# Altium schematic sheet Power.SchDoc(Power)
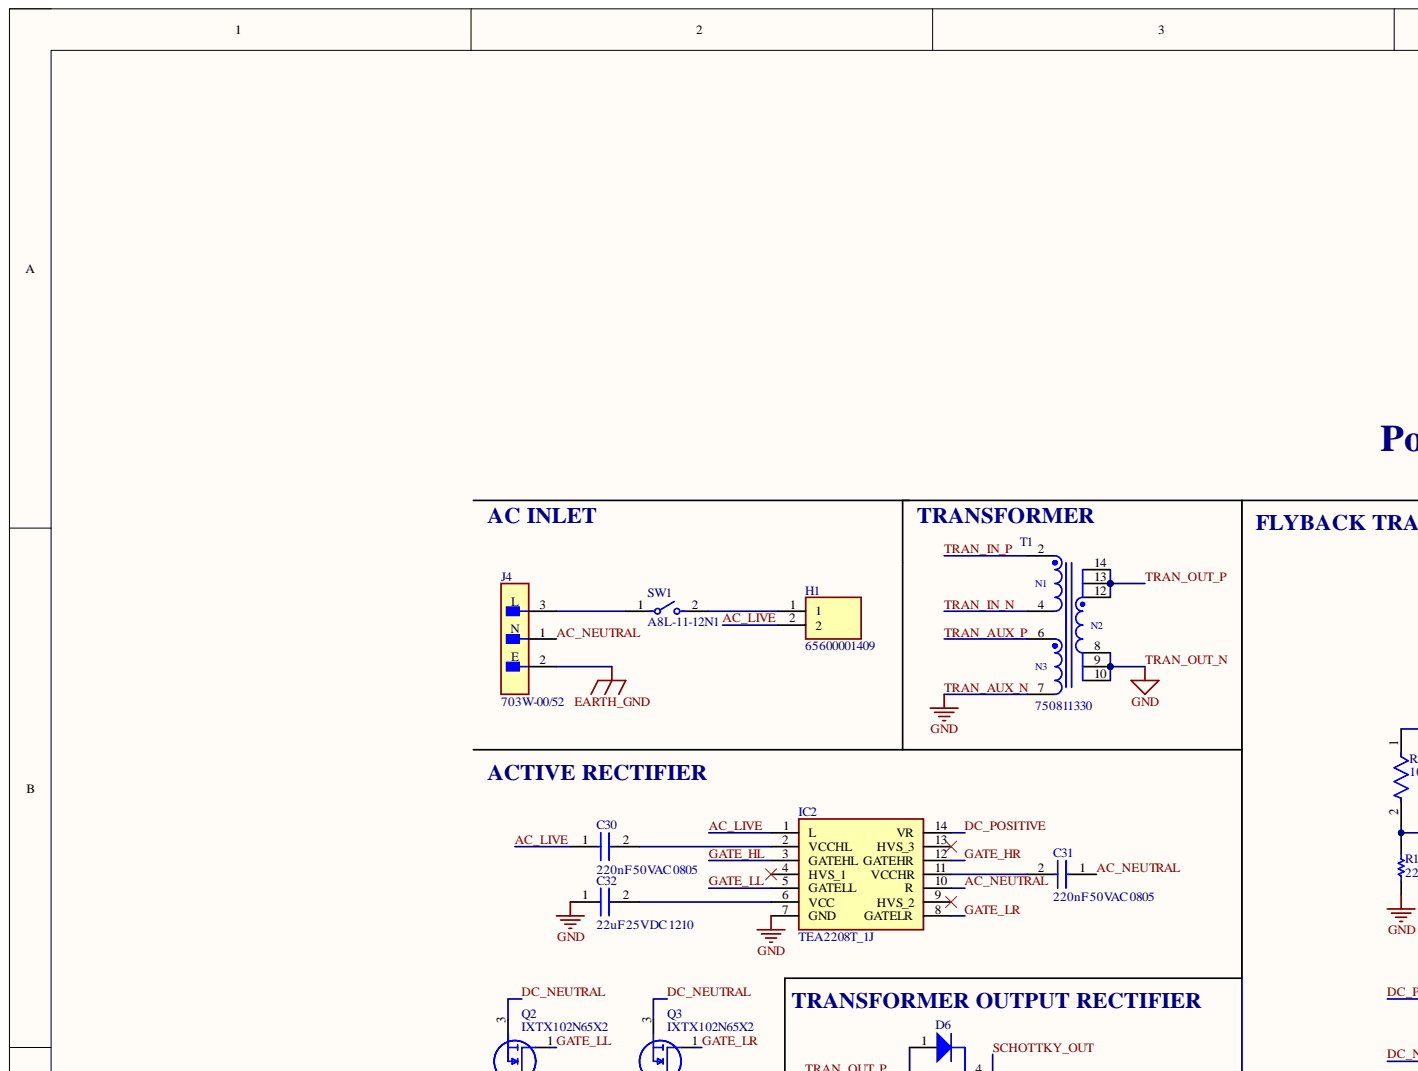
[diagram p0: Power.SchDoc, top left]
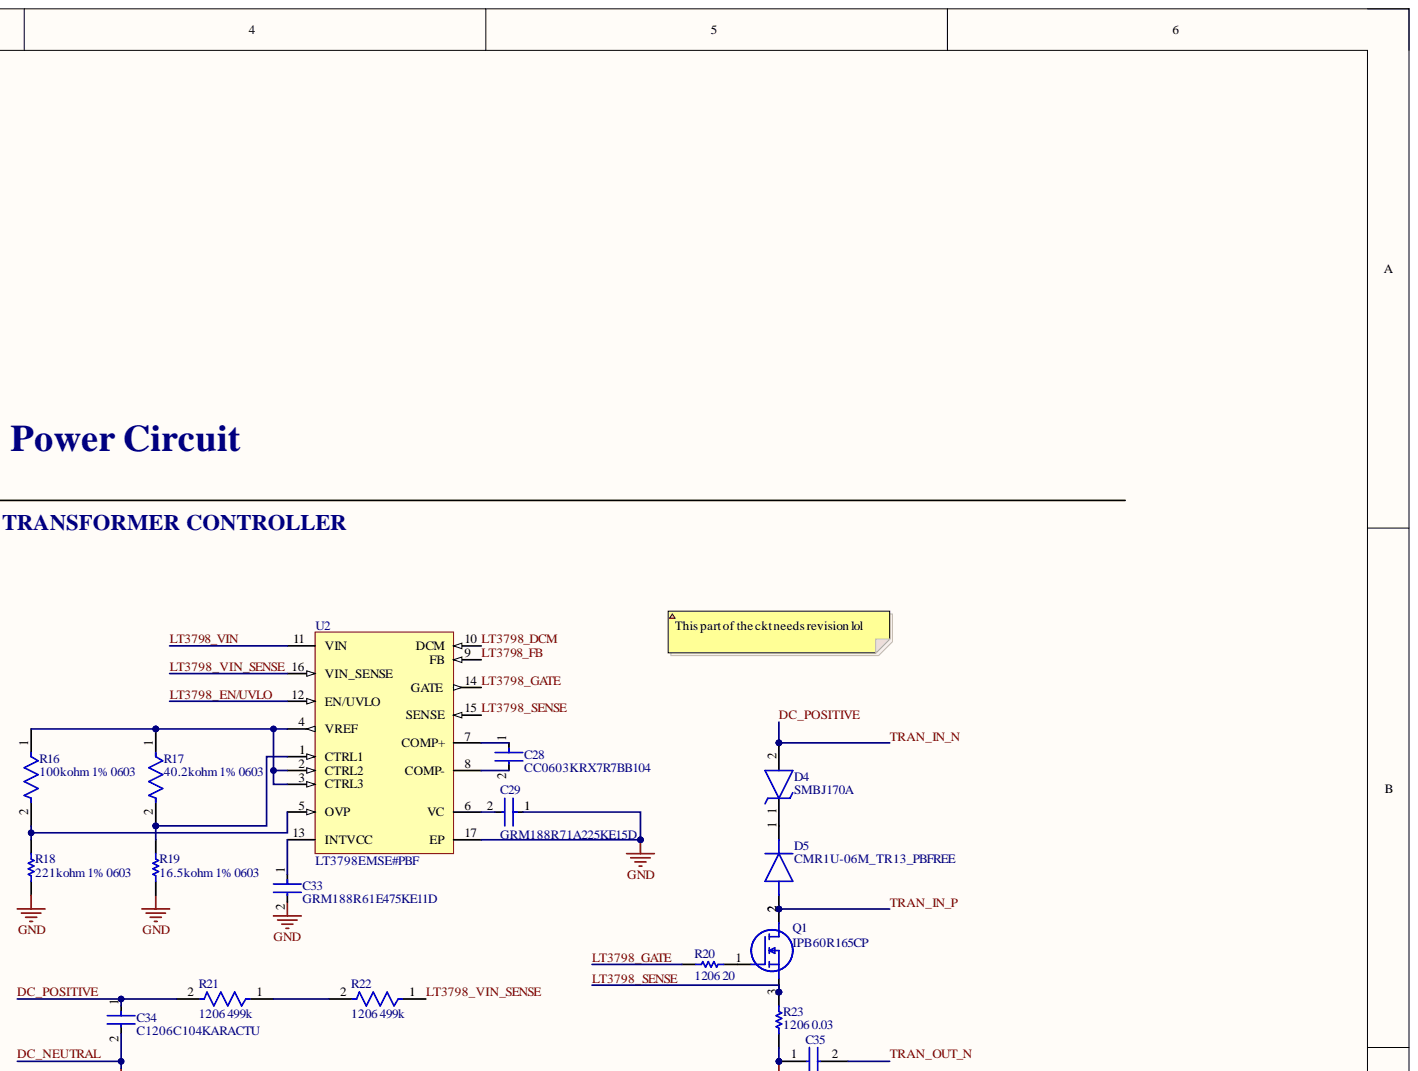
[diagram p1: Power.SchDoc, top right]
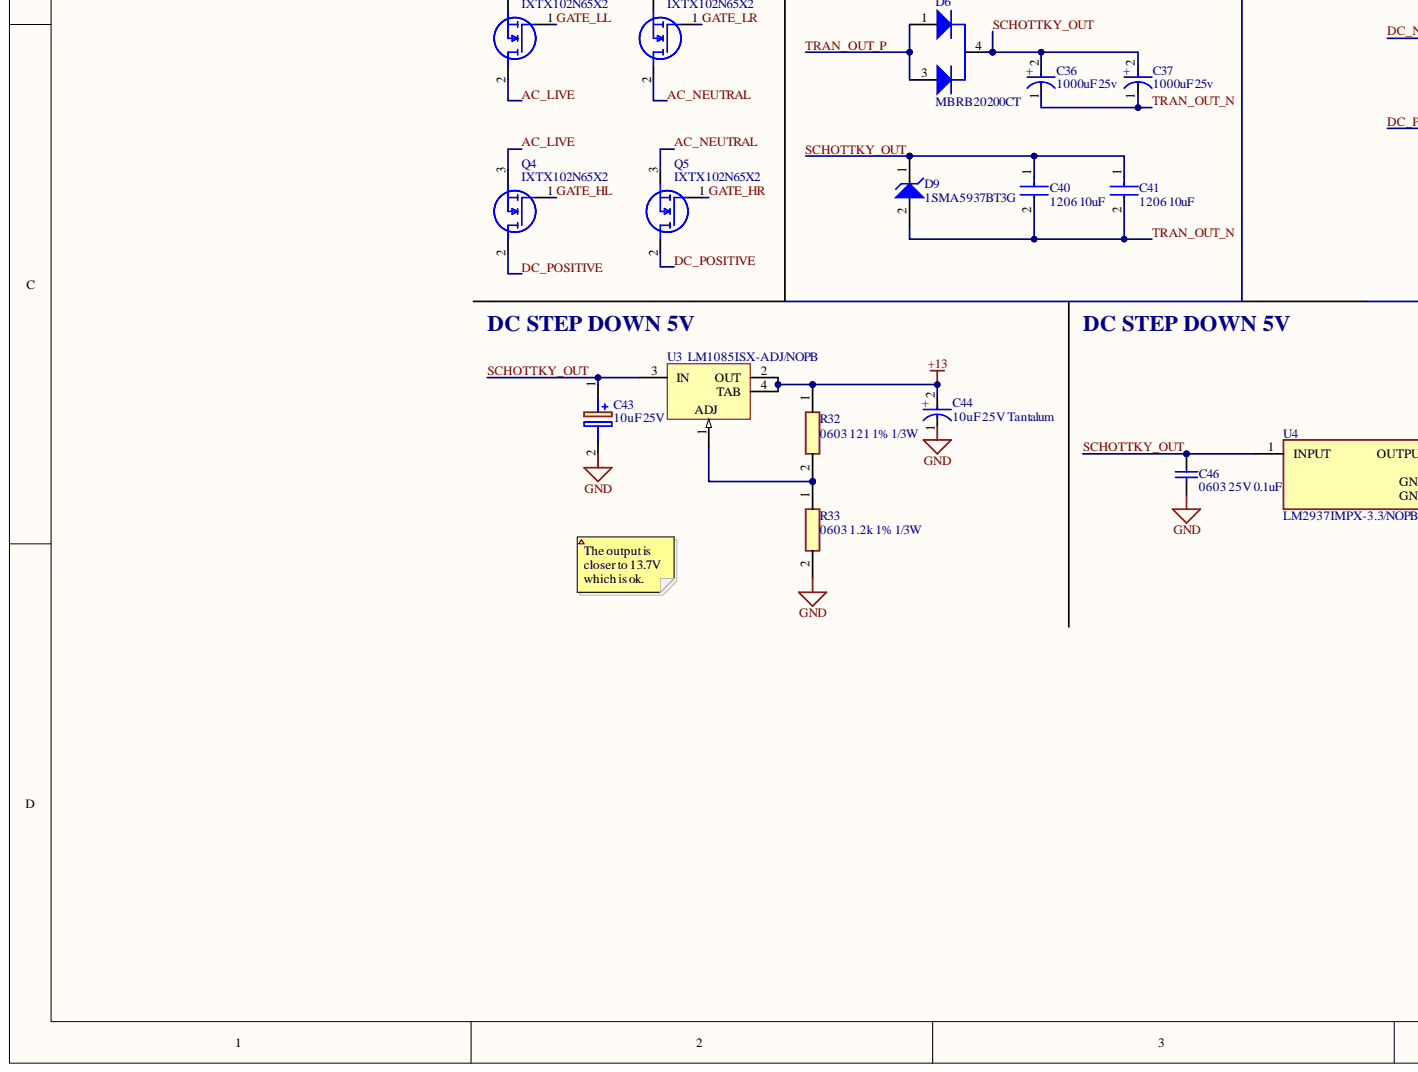
[diagram p2: Power.SchDoc, bottom left]
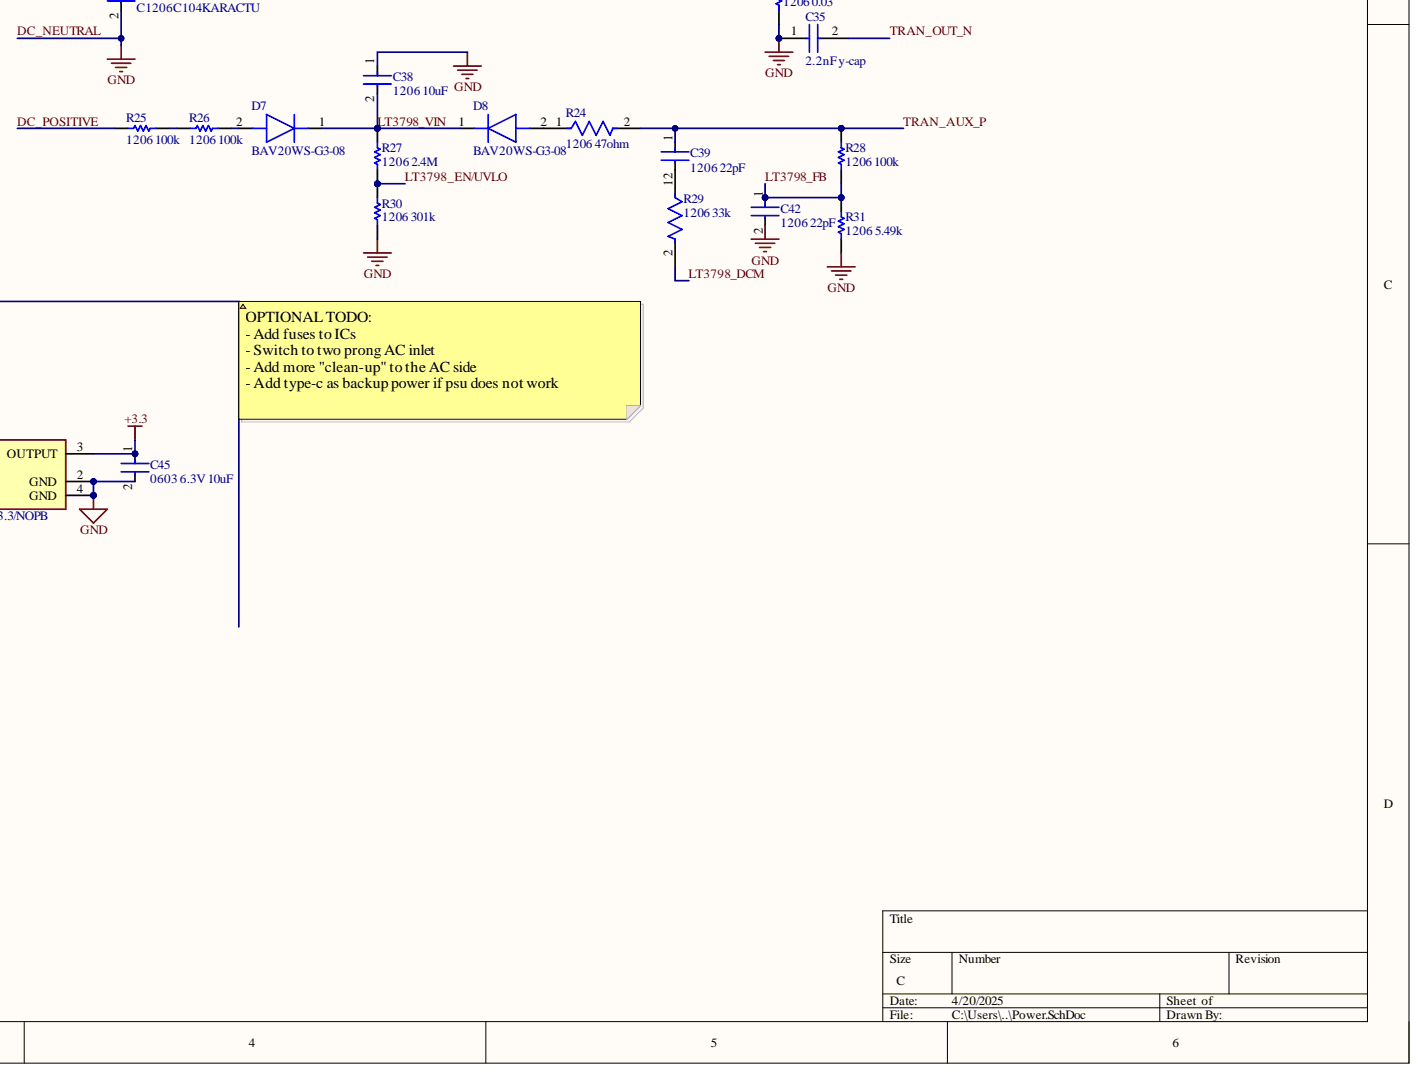
[diagram p3: Power.SchDoc, bottom right]

## Parts
C28  CC0603KRX7R7BB104  [FP-CC0603-DA-MFG]
C29  GRM188R71A225KE15D  [FP-GRM188-0_1-e0_2_0_5-MFG]
C30  220nF 50VAC 0805  [CAPC2012X145N]
C31  220nF 50VAC 0805  [CAPC2012X145N]
C32  22uF 25VDC 1210  [CAPC3225X200N]
C33  GRM188R61E475KE11D  Case Code (Imperial): 0603  [FP-GRM188-0_15-IPC_C]
C34  C1206C104KARACTU  [FP-C1206C-EB-MFG]
C35  2.2nF y-cap  [MKY22W12203D00KSSD]
C36  1000uF 25v  [FP-FKH13-MFG]
C37  1000uF 25v  [FP-FKH13-MFG]
C38  1206 10uF  Capacitance: 10uF  Case Code (Imperial): 1206  [FP-GRM31C-0_2-e0_3_0_8-MFG]
C39  1206 22pF  value 22 pF  [FP-C1206C-EB-MFG]
C40  1206 10uF  Case Code (Imperial): 1206  [FP-GRM31C-0_2-e0_3_0_8-MFG]
C41  1206 10uF  Capacitance: 10uF  Case Code (Imperial): 1206  [FP-GRM31C-0_2-e0_3_0_8-MFG]
C42  1206 22pF  value 22 pF  [FP-C1206C-EB-MFG]
C43  10uF 25V  [CAPPRD200W55D500H1200]
C44  10uF 25V Tantalum  Capacitance: 10uF  Voltage Rating: 25V  [FP-TAJB-MFG]
C45  0603 6.3V 10uF  Case Code (Imperial): 0603  Voltage: 6.3V  [FP-GRM188-0_1-e0_2_0_55-IPC_A]
C46  0603 25V 0.1uF  [CAPC1608X87X35LL15T15]
D4  SMBJ170A  [DIOM5336X244N]
D5  CMR1U-06M_TR13_PBFREE  [DIOM5226X262N]
D6  MBRB20200CT  [ONSC-D2PAK-3-418B-04_V]
D7  BAV20WS-G3-08  [SOD2713X115N]
D8  BAV20WS-G3-08  [SOD2713X115N]
D9  1SMA5937BT3G  [ONSC-SMA-2-403D-02_F_V]
H1  65600001409  [65600001409]
IC2  TEA2208T_1J  [SOIC127P600X175-14N]
J4  703W-00/52  [FP-703W-00-52-MFG]
Q1  IPB60R165CP  [IPB60R165CP]
Q2  IXTX102N65X2  [TO546P531X1980X2918-3P]
Q3  IXTX102N65X2  [TO546P531X1980X2918-3P]
Q4  IXTX102N65X2  [TO546P531X1980X2918-3P]
Q5  IXTX102N65X2  [TO546P531X1980X2918-3P]
R16  100kohm 1% 0603  [FP-CRCW0603-e3-IPC_C]
R17  40.2kohm 1% 0603  Case Code (Imperial): 0603  Resistance Tolerance: 1%  [FP-ERJ3EK-IPC_C]
R18  221kohm 1% 0603  [RESC1608X55X25LL10T15]
R19  16.5kohm 1% 0603  [RESC1608X55X25NL10T15]
R20  1206 20  [RESC3216X70X40ML20T20]
R21  1206 499k  Case Code (Imperial): 1206  [FP-CRCW1206-e3-IPC_A]
R22  1206 499k  Case Code (Imperial): 1206  [FP-CRCW1206-e3-IPC_A]
R23  1206 0.03  [RESC3216X89X51NL25T25]
R24  1206 47ohm  Case Code (Imperial): 1206  [FP-CRCW1206-e3-IPC_C]
R25  1206 100k  [RESC3216X60X45NL10T20]
R26  1206 100k  [RESC3216X60X45NL10T20]
R27  1206 2.4M  [RESC3116X65X40NL10T20]
R28  1206 100k  [RESC3216X60X45NL10T20]
R29  1206 33k  Case Code (Imperial): 1206  [FP-CRCW1206-e3-IPC_B]
R30  1206 301k  [RESC3216X60X45NL10T20]
R31  1206 5.49k  [RESC3216X60X45LL10T20]
R32  0603 121 1% 1/3W  [RESC1608X55N]
R33  0603 1.2k 1% 1/3W  [RESC1608X55N]
SW1  A8L-11-12N1  [FP-A8L-11-12N1-MFG]
T1  750811330  [ETD34_750811330]
U2  LT3798EMSE#PBF  [LT-MSE-16-EDP_L]
U3  LM1085ISX-ADJ/NOPB  [KTT0003B_M]
U4  LM2937IMPX-3.3/NOPB  [FP-DCY0004A-IPC_A]

## Nets
+3.3: C45.1 U4.3(OUTPUT)
+13: C44.2 R32.1 U3.2(OUT) U3.4(TAB)
EARTH_GND: J4.2
AC_LIVE: C30.1 H1.2 IC2.1(L) Q2.2 Q4.3
AC_NEUTRAL: C31.1 IC2.10(R) J4.1 Q3.2 Q5.3
DC_NEUTRAL: C29.1 C32.1 C33.2 C34.2 C35.1 C35.2 C36.1 C37.1 C38.1 C40.2 C41.2 C42.2 C43.2 C44.1 C45.2 C46.2 D9.2 IC2.7(GND) Q2.3 Q3.3 R18.2 R19.2 R23.2 R30.2 R31.2 R33.2 T1.7 T1.8 T1.9 T1.10 U2.17(EP) U4.2(GND) U4.4(GND)
DC_POSITIVE: C34.1 D4.2 IC2.14(VR) Q4.2 Q5.2 R21.2 R25.1 T1.4
GATE_HL: IC2.3(GATEHL) Q4.1
GATE_HR: IC2.12(GATEHR) Q5.1
GATE_LL: IC2.5(GATELL) Q2.1
GATE_LR: IC2.8(GATELR) Q3.1
LT3798_DCM: R29.2 U2.10(DCM)
LT3798_EN/UVLO: R27.2 R30.1 U2.12(EN/UVLO)
LT3798_FB: C42.1 R28.2 R31.1 U2.9(FB)
LT3798_GATE: R20.1 U2.14(GATE)
LT3798_SENSE: Q1.3 R23.1 U2.15(SENSE)
LT3798_VIN: C38.2 D7.1 D8.1 R27.1 U2.11(VIN)
LT3798_VIN_SENSE: R22.1 U2.16(VIN_SENSE)
SCHOTTKY_OUT: C36.2 C37.2 C40.1 C41.1 C43.1 C46.1 D6.4 D9.1 U3.3(IN) U4.1(INPUT)
TRAN_AUX_P: C39.1 R24.2 R28.1 T1.6
TRAN_IN_P: D5.2 Q1.2 T1.2
TRAN_OUT_P: D6.1 D6.3 T1.12 T1.13 T1.14
NetC28_1: C28.1 U2.7(COMP+)
NetC28_2: C28.2 U2.8(COMP-)
NetC29_2: C29.2 U2.6(VC)
NetC30_2: C30.2 IC2.2(VCCHL)
NetC31_2: C31.2 IC2.11(VCCHR)
NetC32_2: C32.2 IC2.6(VCC)
NetC33_1: C33.1 U2.13(INTVCC)
NetC39_2: C39.2 R29.1
NetD4_1: D4.1 D5.1
NetD7_2: D7.2 R26.2
NetD8_2: D8.2 R24.1
NetH1_1: H1.1 SW1.2
NetJ4_3: J4.3 SW1.1
NetQ1_1: Q1.1 R20.2
NetR16_1: R16.1 R17.1 U2.2(CTRL2) U2.3(CTRL3) U2.4(VREF)
NetR16_2: R16.2 R18.1 U2.5(OVP)
NetR17_2: R17.2 R19.1 U2.1(CTRL1)
NetR21_1: R21.1 R22.2
NetR25_2: R25.2 R26.1
NetR32_2: R32.2 R33.1 U3.1

Not connected: IC2 4(HVS_1) 9(HVS_2) 13(HVS_3)

## Library data of the parts
C28 = CMP-2000-05002-2: CAP CER 0.1UF 16V X7R 0603; Manufacturer: YAGEO; Capacitance: 100 nF; Material: Ceramic; Temperature Coefficient: 15%; Tolerance: 0.1; Value: 100 nF; Automotive: No; Category: Cap; Component Kind: Standard; ComponentLink2Description: Datasheet; ComponentLink2URL: http://www.yageo.com/documents/recent/UPY-GPHC_X7R_6.3V-to-50V_18.pdf; Device Class L1: Passive Components; Device Class L2: Capacitors; Device Class L3: Ceramic Capacitors; Digikey Description: CAP CER 0.1UF 16V X7R 0603; Footprint Url: http://www.yageo.com/exep/pages/download/literatures/UPY-C_GEN_24.pdf; Height: 0.9mm; Lead Free: Yes; Library Name: Altium Content Vault; Manufacturer Part Number: CC0603KRX7R7BB104; Mouser Description: Multilayer Ceramic Capacitor, CC Series, 0.1 - F, - 10%, X7R, 16 V, 0603 [1608 Metric]; Octopart: https://octopart.com/cc0603krx7r7bb104-yageo-192941; Package: CC0603-DA; RoHS: TRUE; Temperature: -55°C to +125°C; Temperature Characteristic: X7R; Temperature Range High: +125°C; Temperature Range Low: -55°C; Voltage: 16 V; Voltage Rating: 16 V
C29 = CMP-2006-02836-2: Chip Multilayer Ceramic Capacitors for General Purpose, 0603, 2.2uF, X7R, 15%, 10%, 10V; Capacitance: 2.2uF; Case Code (Imperial): 0603; Case Code (Metric): 1608; Case/Package: 0603; Component Kind: Standard; Depth: 0.8mm; Dielectric: X7R; Height: 0.8mm; Lead Free: Lead Free; Length: 1.6mm; Library Name: Altium Content Vault; Material: Ceramic; Max Operating Temperature: 125°C; Min Operating Temperature: -55°C; Mount: Surface Mount; Number of Pins: 2; Package Quantity: 1; Packaging: Cut Tape; REACH SVHC: No SVHC; Termination: SMD/SMT; Thickness: 0.035inch; Tolerance: 10%; Voltage: 10V; Voltage Rating: 10V; Voltage Rating (DC): 10V; Weight: 7.1E-05oz; Width: 0.031inch
C30 C31 = AC0805KFX7R9BB224: Capacitor; Manufacturer_Name: KEMET; Component Kind: Standard; Datasheet Link: http://www.yageo.com/documents/recent/UPY-AC_NP0X7R_16V-to-630V_12.pdf; Height: 1.45mm; Manufacturer_Part_Number: AC0805KFX7R9BB224
C32 = 25MU225MB23225: Capacitor; Manufacturer_Name: Rubycon; Component Kind: Standard; Datasheet Link: http://www.rubycon.co.jp/en/catalog/e_pdfs/pmlcap/e_MU.pdf; Height: 2mm; Manufacturer_Part_Number: 25MU225MB23225; Mouser Part Number: 232-25MU225MB23225; Mouser Price/Stock: https://www.mouser.co.uk/ProductDetail/Rubycon/25MU225MB23225?qs=T3oQrply3y80th0zXFlwOw%3D%3D
C33 = CMP-2006-02523-2: Chip Multilayer Ceramic Capacitors for General Purpose, 0603, 4.7uF, X5R, 15%, 10%, 25V; Capacitance: 4.7uF; Case Code (Metric): 1608; Case/Package: 0603; Component Kind: Standard; Depth: 0.8mm; Dielectric: X5R; Height: 0.8mm; Lead Free: Lead Free; Length: 1.6mm; Library Name: Altium Content Vault; Material: Ceramic; Max Operating Temperature: 85°C; Min Operating Temperature: -55°C; Mount: Surface Mount; Package Quantity: 4000; Packaging: Cut Tape; REACH SVHC: No SVHC; Thickness: 0.031inch; Tolerance: 10%; Voltage: 25V; Voltage Rating: 25V; Voltage Rating (DC): 25V; Width: 0.031inch
C34 = CMP-1037-04229-2: CAP CER 0.1UF 250V X7R 1206; Manufacturer: KEMET; Automotive Grade: Grade 0; Capacitance: 0.10 μF; Material: Ceramic; Temperature Coefficient: 15%; Tolerance: 0.1; Value: 0.10 μF; Automotive: Yes; Category: Cap; Component Kind: Standard; ComponentLink2Description: Datasheet; ComponentLink2URL: https://content.kemet.com/datasheets/KEM_C1007_X8R_ULTRA_150C_SMD.pdf; Device Class L1: Passive Components; Device Class L2: Capacitors; Device Class L3: Ceramic Capacitors; Digikey Description: CAP CER 0.1UF 250V X7R 1206; Height: 0.88mm; Ipc Land Pattern Name: CAPC320160X78; Lead Free: Yes; Library Name: Altium Content Vault; Manufacturer Part Number: C1206C104KARACTU; Mouser Description: Multilayer Ceramic Capacitors MLCC - SMD/SMT 250volts 0.1uF X7R 10%; Octopart: https://octopart.com/c1206c104karactu-kemet-193627; Package: C1206C-EB; RoHS: TRUE; Temperature: -55°C to +150°C; Temperature Characteristic: X7R; Temperature Range High: +150°C; Temperature Range Low: -55°C; Voltage Rating: 250 V
C35 = MKY22W12203D00KSSD: Capacitor; Manufacturer_Name: WIMA; Component Kind: Standard; Datasheet Link: https://eu.mouser.com/datasheet/2/440/e_WIMA_MKP_Y2-1139883.pdf; Height: 9.5mm; Manufacturer_Part_Number: MKY22W12203D00KSSD; Mouser Part Number: 505-MKY22W12203DKSSD; Mouser Price/Stock: https://www.mouser.co.uk/ProductDetail/WIMA/MKY22W12203D00KSSD?qs=z5u5uZJqM4Oanxl7ljBHlQ%3D%3D
C36 C37 = CMP-05427-002158-1: CAP ALUM 1000UF 20% 25V SMD; Capacitance: 1mF; ESR (Equivalent Series Resistance): 60mR; Life (Hours): 5000Hour; Polarity: Polar; Ripple Current: 1.1A; Case/Package: Radial; Component Kind: Standard; Construction: Cylindrical; Diameter: 12.5mm; Height: 13.5mm; Height - Seated (Max): 0.55inch; Impedance: 60mR; Lead Free: Lead Free; Length: 13.5mm; Library Name: Altium Content Vault; Max Operating Temperature: 105°C; Min Operating Temperature: -55°C; Mount: Surface Mount; Package Quantity: 200; Packaging: Tape and Reel; Radiation Hardening: No; Ratings: AEC-Q200; Reach SVHC Compliant: Yes; Ripple Current (AC): 1.1A; RoHS Compliant: Yes; Series: FK; Termination: SMD/SMT; Tolerance: 20%; Voltage: 25V; Voltage Rating: 25V; Voltage Rating (DC): 25V
C38 C40 C41 = CMP-06035-003589-1: Chip Multilayer Ceramic Capacitors for General Purpose, 1206, 10uF, X7R, 15%, 10%, 25V; Case Code (Metric): 3216; Case/Package: 1206; Component Kind: Standard; Depth: 1.6mm; Dielectric: X7R; Height: 1.6mm; Lead Free: Lead Free; Length: 3.2mm; Library Name: Altium Content Vault; Material: Ceramic; Max Operating Temperature: 125°C; Min Operating Temperature: -55°C; Mount: Surface Mount; Number of Pins: 2; Package Quantity: 1; Packaging: Tape and Reel; Radiation Hardening: No; REACH SVHC: No SVHC; Termination: SMD/SMT; Thickness: 0.071inch; Tolerance: 10%; Voltage: 25V; Voltage Rating: 25V; Voltage Rating (DC): 25V; Width: 1.6mm
  C40: Capacitance: 10uF
C39 C42 = CMP-1037-00989-2: CAP CER 22PF 100V 5% NP0 1206; Manufacturer: KEMET; Manufacturer Part Number: C1206C220J1GACTU; Automotive Grade: Grade 0; Material: Ceramic; Temperature Characteristic: C0G; Temperature Coefficient: 15%; Tolerance: 0.05; Automotive: Yes; Capacitance: 22 pF; Category: Cap; Component Kind: Standard; ComponentLink2Description: Datasheet; ComponentLink2URL: https://content.kemet.com/datasheets/KEM_C1007_X8R_ULTRA_150C_SMD.pdf; Device Class L1: Passive Components; Device Class L2: Capacitors; Device Class L3: Ceramic Capacitors; Digikey Description: CAP CER 22PF 100V 5% NP0 1206; Height: 0.88mm; Ipc Land Pattern Name: CAPC320160X78; Lead Free: Yes; Library Name: Altium Content Vault; Mouser Description: 1206 22pF Ceramic Multilayer Capacitor; 100VDC; 125degC; C0G Dielec; +/-5% SMD; Octopart: https://octopart.com/c1206c220j1gactu-kemet-9145181; Package: C1206C-EB; RoHS: TRUE; Temperature: -55°C to +150°C; Temperature Range High: +150°C; Temperature Range Low: -55°C; Value: 22 pF; Voltage Rating: 100 V
C43 = ECA-1EM100: Capacitor Polarised; Manufacturer_Name: Panasonic; Arrow Part Number: ECA-1EM100; Arrow Price/Stock: https://www.arrow.com/en/products/eca1em100/panasonic; Component Kind: Standard; Datasheet Link: https://componentsearchengine.com/Datasheets/2/ECA-1EM100.pdf; Height: 12mm; Manufacturer_Part_Number: ECA-1EM100; Mouser Part Number: 667-ECA-1EM100; Mouser Price/Stock: https://www.mouser.com/Search/Refine.aspx?Keyword=667-ECA-1EM100
C44 = CMP-04424-000481-1: 10uF 25V  ±10% 2.5Ω 1210  SMD Tantalum Capacitor; Dissipation Factor: 6%; ESR (Equivalent Series Resistance): 2.5R; Leakage Current: 2.5uA; Polarity: Polar; Case Code (Imperial): 1210; Case Code (Metric): 3528; Case/Package: 1210; Component Kind: Standard; Construction: Flat; Depth: 2.8mm; Features: General Purpose; Height: 2.1mm; Height - Seated (Max): 0.083inch; Lead Free: Lead Free; Length: 3.5mm; Library Name: Altium Content Vault; Max Operating Temperature: 125°C; Min Operating Temperature: -55°C; Mount: Surface Mount; Package Quantity: 1; Packaging: Tape and Reel; Radiation Hardening: No; REACH SVHC: No SVHC; RoHS Compliant: Yes; Series: TAJ; Termination: SMD/SMT; Tolerance: 10%; Voltage Rating (DC): 25V; Weight: 0.000564oz; Width: 0.11inch
C45 = CMP-2006-02792-2: Chip Multilayer Ceramic Capacitors for General Purpose, 0603, 10uF, X5R, 15%, 20%, 6.3V; Capacitance: 10uF; Case Code (Metric): 1608; Case/Package: 0603; Component Kind: Standard; Depth: 0.8mm; Dielectric: X5R; Height: 0.8mm; Lead Free: Lead Free; Length: 1.6mm; Library Name: Altium Content Vault; Material: Ceramic; Max Operating Temperature: 85°C; Min Operating Temperature: -55°C; Mount: Surface Mount; Package Quantity: 4000; Packaging: Tape and Reel; Radiation Hardening: No; REACH SVHC: No SVHC; Termination: SMD/SMT; Thickness: 0.035inch; Tolerance: 20%; Voltage Rating: 6.3V; Voltage Rating (DC): 6.3V; Width: 0.031inch
C46 = CMP-2006-03713-1: Manufacturer: Kemet; Part Number: C0603C105K3RACTU; Component Kind: Standard; Library Name: Altium Content Vault
D4 = SMBJ170A: TVS Diode (Uni-directional); Manufacturer_Name: HY Electronic; Component Kind: Standard; Height: 2.44mm; Library Name: SamacSys.SchLib; Manufacturer_Part_Number: SMBJ170A
D5 = CMR1U-06M_TR13_PBFREE: Diode; Manufacturer_Name: Central Semiconductor; Manufacturer_Part_Number: CMR1U-06M TR13 PBFREE; Component Kind: Standard; Datasheet Link: https://my.centralsemi.com/datasheets/CMR1U01M.PDF; Height: 2.62mm; Library Name: SamacSys.SchLib; Mouser Part Number: 610-CMR1U-06M; Mouser Price/Stock: https://www.mouser.co.uk/ProductDetail/Central-Semiconductor/CMR1U-06M-TR13-PBFREE/?qs=u16ybLDytRaiTbcu0RAPhw%3D%3D
D6 = CMP-1055-00130-1: SWITCHMODE(TM) Power Rectifier, Dual Schottky Rectifiers, 3-Pin D2PAK, Tube; Manufacturer: On Semiconductor; Configuration: Common Cathode; IFSM Max (A): 150; Note1: 0; Component Kind: Standard; ComponentLink1Description: Manufacturer URL; ComponentLink1URL: http://www.onsemi.com/; ComponentLink2Description: Datasheet; ComponentLink2URL: http://www.onsemi.cn/pub_link/Collateral/MBRB20200CT-D.PDF; ComponentLink3Description: Package Specification; ComponentLink3URL: http://www.onsemi.com/pub_link/Collateral/418B-04.PDF; DatasheetVersion: Rev. 7; Library Name: Altium Content Vault; Mounting Technology: Surface Mount; PackageDescription: 3-Pin Flange Mount, Body 9.145 x 9.97 mm, Pitch 2.54 mm; PackageReference: D2PAK-3-418B-04; PackageVersion: Rev. K, 09/2008; Packing: Tube; PartNumber: MBRB20200CT; RoHS: FALSE
D7 D8 = BAV20WS-G3-08: Diode; Manufacturer_Name: Vishay; Arrow Part Number: BAV20WS-G3-08; Arrow Price/Stock: https://www.arrow.com/en/products/bav20ws-g3-08/vishay?region=nac; Component Kind: Standard; Datasheet Link: https://componentsearchengine.com/Datasheets/1/BAV20WS-G3-08.pdf; Height: 1.15mm; Library Name: SamacSys.SchLib; Manufacturer_Part_Number: BAV20WS-G3-08; Mouser Part Number: 78-BAV20WS-G3-08; Mouser Price/Stock: https://www.mouser.co.uk/ProductDetail/Vishay-Semiconductors/BAV20WS-G3-08?qs=ROh%252BA47s9d2Jk9K4pPeq%252BQ%3D%3D
D9 = CMP-1060-00094-1: 1.5 W Zener Voltage Regulator, 2-Pin SMA, Pb-Free, Tape and Reel; Manufacturer: On Semiconductor; VZ Typ (V): 33; Component Kind: Standard; ComponentLink1Description: Manufacturer URL; ComponentLink1URL: http://www.onsemi.com/; ComponentLink2Description: Datasheet; ComponentLink2URL: http://www.onsemi.com/pub_link/Collateral/1SMA5913BT3-D.PDF; ComponentLink3Description: Package Specification; ComponentLink3URL: http://www.onsemi.com/pub_link/Collateral/1SMA5913BT3-D.PDF; DatasheetVersion: Rev. 8; Library Name: Altium Content Vault; Mounting Technology: Surface Mount; PackageDescription: 2-Pin Small Molded Package, J-Bend Lead, Body 2.605 x 4.315 mm; PackageReference: SMA-2-403D-02_F; PackageVersion: Rev. F, 11/2008; Packing: Tape and Reel; PartNumber: 1SMA5937BT3G; RoHS: Pb-Free
H1 = 65600001409: Hardware; Manufacturer_Name: LITTELFUSE; Arrow Part Number: 65600001409; Arrow Price/Stock: https://www.arrow.com/en/products/65600001409/littelfuse?utm_currency=USD&region=nac; Component Kind: Standard; Datasheet Link: https://www.littelfuse.com/media?resourcetype=datasheets&itemid=7143dbe7-66cc-46d0-a668-04c7d18969fc&filename=littelfuse-fuse-block-656-datasheet; Height: 12.7mm; Manufacturer_Part_Number: 65600001409; Mouser Part Number: 576-65600001409; Mouser Price/Stock: https://www.mouser.co.uk/ProductDetail/Littelfuse/65600001409?qs=ubGq6M%252B9ToVYxvlW0XHBFQ%3D%3D
IC2 = TEA2208T_1J: Integrated Circuit; Manufacturer_Name: NXP; Manufacturer_Part_Number: TEA2208T/1J; Component Kind: Standard; Datasheet Link: https://www.nxp.com/docs/en/data-sheet/TEA2208T.pdf; Height: 1.75mm; Mouser Part Number: 771-TEA2208T/1J; Mouser Price/Stock: https://www.mouser.co.uk/ProductDetail/NXP-Semiconductors/TEA2208T-1J?qs=wnTfsH77Xs7i9IS7OBVq2w%3D%3D
J4 = CMP-64678-000001-1: PWR ENT RCPT IEC320-C14 PNL SLDR; Approvals: UL; Connector Type: Receptacle; Current: 15A; Fuse: No; Max Voltage Rating (AC): 250V; Number of Contacts: 3; Number of Poles: 2; Panel Thickness: 0.12inch; Pitch: 7mm; Color: Black; Component Kind: Standard; Contact Plating: Tin; Current Rating: 15A; Gender: Receptacle; Housing Material: Thermoplastic; Lead Free: Lead Free; Library Name: Altium Content Vault; Max Current Rating: 15A; Mount: Through Hole; Number of Positions: 3; Orientation: Right Angle; Package Quantity: 100; Packaging: Bulk; REACH SVHC: No SVHC; Termination: Solder; Voltage Rating: 250V; Voltage Rating (AC): 250V; Voltage Rating (DC): 250V
Q1 = IPB60R165CP: MOSFET (N-Channel); Manufacturer_Name: Infineon; Component Kind: Standard; Datasheet Link: https://www.infineon.com/dgdl/Infineon-IPB60R165CP-DS-v02_01-en.pdf?fileId=db3a304412b407950112b42dd38f48fc; Height: 4.826mm; Library Name: SamacSys.SchLib; Manufacturer_Part_Number: IPB60R165CP; Mouser Part Number: 726-IPB60R165CP; Mouser Price/Stock: https://www.mouser.co.uk/ProductDetail/Infineon-Technologies/IPB60R165CP?qs=mzcOS1kGbgdcrfUvmAosLQ%3D%3D
Q2-Q5 = IXTX102N65X2: MOSFET (N-Channel); Manufacturer_Name: LITTELFUSE; Component Kind: Standard; Datasheet Link: http://ixapps.ixys.com/DataSheet/DS100655B(IXTK-TX102N65X2).pdf; Height: 5.31mm; Manufacturer_Part_Number: IXTX102N65X2; Mouser Part Number: 747-IXTX102N65X2; Mouser Price/Stock: https://www.mouser.com/Search/Refine.aspx?Keyword=747-IXTX102N65X2
R16 = CMP-2000-03823-2: RES Thick Film, 100kΩ, 5%, 0.1W, 200ppm/°C, 0603; Max Power Dissipation: 100mW; Resistance: 100kR; Voltage Rating: 75V; Case Code (Imperial): 0603; Case Code (Metric): 1608; Case/Package: 0603; Component Kind: Standard; Composition: Thick Film; Contact Plating: Tin; Depth: 0.85mm; Features: Automotive AEC-Q200; Height: 0.02inch; Length: 1.55mm; Library Name: Altium Content Vault; Max Operating Temperature: 155°C; Military Standard: Not; Min Operating Temperature: -55°C; Mount: Surface Mount; Number of Pins: 2; Number of Terminations: 2; Package Quantity: 1; Packaging: Tape and Reel; Power Rating: 100mW; Radiation Hardening: No; Temperature Coefficient: 200ppm/°C; Termination: SMD/SMT; Tolerance: 5%; Weight: 7.1E-05oz; Width: 0.85mm; Working Voltage: 75V
R17 = CMP-2000-00486-2: RES SMD 40.2K OHM 1% 1/10W 0603; Max Power Dissipation: 100mW; Resistance: 40.2kR; Temperature Coefficient: 100ppm/°C; Voltage Rating: 75V; Voltage Rating (DC): 50V; Case Code (Metric): 1608; Case/Package: 0603; Component Kind: Standard; Construction: Rectangular; Contact Plating: Tin; Depth: 0.8mm; Features: Automotive AEC-Q200; Height: 0.45mm; Lead Free: Lead Free; Length: 1.6mm; Library Name: Altium Content Vault; Max Operating Temperature: 155°C; Military Standard: Not; Min Operating Temperature: -55°C; Mount: Surface Mount; Number of Terminations: 2; Package Quantity: 1; Packaging: Tape and Reel; Power Rating: 100mW; Radiation Hardening: No; Resistor Type: Thick Film; RoHS Compliant: Yes; Series: ERJ; Technology: THICK FILM; Termination: SMD/SMT; Tolerance: 1%; Weight: 7.1E-05oz; Width: 0.031inch
R18 = CMP-2000-03957-1: Manufacturer: Yageo; Part Number: RC0603FR-07221KL; Component Kind: Standard; Library Name: Altium Content Vault
R19 = CMP-2000-04912-1: Manufacturer: Yageo; Part Number: RC0603FR-0716K5L; Component Kind: Standard; Library Name: Altium Content Vault
R20 = CMP-2003-04567-1: Manufacturer: KOA Speer; Part Number: RK73B2BTTD200J; Component Kind: Standard; Library Name: Altium Content Vault
R21 R22 = CMP-1014-00815-3: RES Thick Film, 499kΩ, 1%, 0.25W, 100ppm/°C, 1206; Max Power Dissipation: 250mW; Resistance: 499kR; Voltage Rating: 200V; Case Code (Metric): 3216; Case/Package: 1206; Component Kind: Standard; Composition: Thick Film; Contact Plating: Tin; Depth: 1.6mm; Features: Automotive AEC-Q200; Height: 0.55mm; Lead Free: Lead Free; Length: 3.2mm; Library Name: Altium Content Vault; Max Operating Temperature: 155°C; Military Standard: Not; Min Operating Temperature: -55°C; Mount: Surface Mount; Number of Pins: 2; Number of Terminations: 2; Package Quantity: 10; Packaging: Tape and Reel; Power Rating: 250mW; Radiation Hardening: No; Temperature Coefficient: 100ppm/°C; Termination: Solder; Tolerance: 1%; Voltage Rating (DC): 200V; Weight: 0.000353oz; Width: 1.6mm
R23 = CMP-2003-04356-1: Manufacturer: Vishay Dale; Part Number: WSL1206R0300FEA; Component Kind: Standard; Library Name: Altium Content Vault
R24 = CMP-2003-02215-2: RES Thick Film, 47Ω, 1%, 0.25W, 100ppm/°C, 1206; Max Power Dissipation: 250mW; Resistance: 47R; Voltage Rating: 200V; Case Code (Metric): 3216; Case/Package: 1206; Component Kind: Standard; Composition: Thick Film; Contact Plating: Tin; Depth: 1.6mm; Features: Automotive AEC-Q200; Height: 0.55mm; Lead Free: Lead Free; Length: 3.2mm; Library Name: Altium Content Vault; Max Operating Temperature: 155°C; Military Standard: Not; Min Operating Temperature: -55°C; Mount: Surface Mount; Number of Terminations: 2; Package Quantity: 10; Packaging: Tape and Reel; Power Rating: 250mW; Radiation Hardening: No; Temperature Coefficient: 100ppm/°C; Termination: SMD/SMT; Tolerance: 1%; Voltage Rating (DC): 200V; Weight: 0.000353oz; Width: 0.063inch
R25 R26 R28 = CMP-1014-00736-2: Manufacturer: Vishay Dale; Part Number: CRCW1206100KFKEA; Component Kind: Standard; Library Name: Altium Content Vault
R27 = CMP-2003-03682-1: Manufacturer: Yageo; Part Number: RC1206FR-072M4L; Component Kind: Standard; Library Name: Altium Content Vault
R29 = CMP-2003-02103-2: RES Thick Film, 33kΩ, 1%, 0.25W, 100ppm/°C, 1206; Max Power Dissipation: 250mW; Resistance: 33kR; Voltage Rating: 200V; Case Code (Metric): 3216; Case/Package: 1206; Component Kind: Standard; Composition: Thick Film; Contact Plating: Tin; Depth: 1.6mm; Features: Automotive AEC-Q200; Height: 0.55mm; Lead Free: Lead Free; Length: 3.2mm; Library Name: Altium Content Vault; Max Operating Temperature: 155°C; Military Standard: Not; Min Operating Temperature: -55°C; Number of Terminations: 2; Package Quantity: 10; Packaging: Tape and Reel; Power Rating: 250mW; Radiation Hardening: No; Temperature Coefficient: 100ppm/°C; Termination: SMD/SMT; Tolerance: 1%; Voltage Rating (DC): 200V; Weight: 0.000353oz; Width: 1.6mm; Working Voltage: 200V
R30 = CMP-2003-02078-1: Manufacturer: Vishay Dale; Part Number: CRCW1206301KFKEA; Component Kind: Standard; Library Name: Altium Content Vault
R31 = CMP-2003-02301-1: Manufacturer: Vishay Dale; Part Number: CRCW12065K49FKEA; Component Kind: Standard; Library Name: Altium Content Vault
R32 = CRCW0603121RFKEAHP: Resistor; Manufacturer_Name: Vishay; Arrow Part Number: CRCW0603121RFKEAHP; Arrow Price/Stock: https://www.arrow.com/en/products/crcw0603121rfkeahp/vishay?region=nac; Component Kind: Standard; Datasheet Link: https://www.vishay.com/docs/20043/crcwhpe3.pdf; Height: 0.55mm; Manufacturer_Part_Number: CRCW0603121RFKEAHP; Mouser Part Number: 71-CRCW0603121RFKEAH; Mouser Price/Stock: https://www.mouser.co.uk/ProductDetail/Vishay-Dale/CRCW0603121RFKEAHP?qs=40TFAHZMFvf7JpHs6aBGEg%3D%3D
R33 = CRCW06031K20FKEAHP: Resistor; Manufacturer_Name: Vishay; Arrow Part Number: CRCW06031K20FKEAHP; Arrow Price/Stock: https://www.arrow.com/en/products/crcw06031k20fkeahp/vishay?region=nac; Component Kind: Standard; Datasheet Link: https://www.vishay.com/docs/20043/crcwhpe3.pdf; Height: 0.55mm; Manufacturer_Part_Number: CRCW06031K20FKEAHP; Mouser Part Number: 71-CRCW06031K20FKEAH; Mouser Price/Stock: https://www.mouser.co.uk/ProductDetail/Vishay-Dale/CRCW06031K20FKEAHP?qs=40TFAHZMFveP9B2Fb67Ndg%3D%3D
SW1 = CMP-08830-000001-1: SWITCH ROCKER SPST 10A 125V; Electrical Life: 10000Cycles; Max Voltage Rating (AC): 250V; Mechanical Life: 50000Cycles; Switch Function: On-Off; Component Kind: Standard; Current Rating: 10A; Library Name: Altium Content Vault; Max Operating Temperature: 55°C; Min Operating Temperature: -20°C; Mount: Panel; Orientation: Straight; Packaging: Bulk; Radiation Hardening: No; RoHS Compliant: Yes; Termination: PC Pins; Throw Configuration: SPST; Voltage Rating (AC): 125V
T1 = CMP-012-00033-1: WE-OLLT Offline Flyback Transformer, THT, ETD34, Ui = 85 - 265V, Uo = 18V / 5A; Manufacturer: Wurth Elektronik; Inductance: 300uH; Input Voltage: 85 - 265V (AC); Insulation Test Voltage: 4500V (AC); Saturation Current: 4A; Switching Frequency: 200kHz; Auxiliary Voltage: 18V; Case/Package: ETD34; Category: Transformers For AC-DC Converter; Component Kind: Standard; Component Type: Standard; ComponentLink1Description: Website Link; ComponentLink1URL: https://www.we-online.com/redexpert/article/750811330?ad; ComponentLink2Description: Datasheet Link; ComponentLink2URL: https://www.we-online.com/redexpert/spec/750811330?ad; Design Item ID: 750811330; Height: 30.48mm; Length: 39.6mm; Library Name: Spartan Racing Altium Workspace; Manufacturer Part Number: 750811330; Match Code: WE-OLLT; Mount: Through Hole; Operating Temperature Max: 125°C; Operating Temperature Min: -40°C; Output Current: 5A; Output Voltage: 18V; Packaging: Tray; Pins: 10; Source: WE-OLLT.SchLib; Width: 43.18mm
U2 = CMP-0491-01149-1: Isolated No Opto-Coupler Flyback Controller with Active PFC, 16-pin SOP (MSE-16-EDP), -40 to 125 degC, Pb-Free; Manufacturer: Linear Technology; Number of Outputs: 1; Component Kind: Standard; ComponentLink1Description: Manufacturer URL; ComponentLink1URL: http://www.linear.com/; ComponentLink2Description: Datasheet; ComponentLink2URL: http://cds.linear.com/docs/en/datasheet/3798fa.pdf; ComponentLink3Description: Package Specification; ComponentLink3URL: http://cds.linear.com/docs/en/packaging/05081667_F_MSE16.pdf; DatasheetVersion: Rev. A; Library Name: Altium Content Vault; Mounting Technology: Surface Mount; PackageDescription: 16-Pin Plastic MSOP, Exposed Die Pad, Body 4.039 x 3 mm, Pitch 0.5 mm; PackageReference: MSE-16; PackageVersion: Rev. F, 05/2013; PartNumber: LT3798EMSE#PBF; RoHS: TRUE
U3 = CMP-0062-00598-5: 3A Low Dropout Positive Regulators, 3-pin TO-263, Pb-Free; Manufacturer: Texas Instruments; CompType: LDO IC; High-Reliability: Y; PackageTopMarking: NSUZXYTTE#*LM1085*IS-ADJ; PowerWise: N; Code_JEDEC: MO-169-AA; Component Kind: Standard; ComponentLink1Description: Datasheet URL; ComponentLink1URL: http://www.ti.com/general/docs/lit/getliterature.tsp?genericPartNumber=LM1085&fileType=pdf; Library Name: Altium Content Vault; PackageDescription: TO-263, Molded, .400 x .390 x .180in, 3 Lead, .1in Pitch, Surface Mount; PackageReference: KTT0003B; PartNumber: LM1085ISX-ADJ/NOPB; PinCount: 3; RoHS: Y
U4 = CMP-04917-000345-1: IC REG LIN 3.3V 400MA SOT223-4; Accuracy: 5%; Dropout Voltage: 500mV; Max Input Voltage: 26V; Min Input Voltage: 4.75V; Number of Outputs: 1; Output Type: Fixed; Polarity: Positive; Quiescent Current: 10mA; Case/Package: TO-261-4; Component Kind: Standard; Lead Free: Lead Free; Library Name: Altium Content Vault; Max Operating Temperature: 125°C; Max Output Current: 400mA; Min Operating Temperature: -40°C; Mount: Surface Mount; Number of Pins: 4; Number of Regulators: 1; Output Current: 400mA; Output Voltage: 3.3V; Output Voltage Accuracy: 5%; Package Quantity: 2000; Packaging: Tape and Reel; Radiation Hardening: No; RoHS Compliant: Yes
